# Altium schematic sheet 4inches_DSI_LCD.SchDoc(4inches_DSI_LCD)
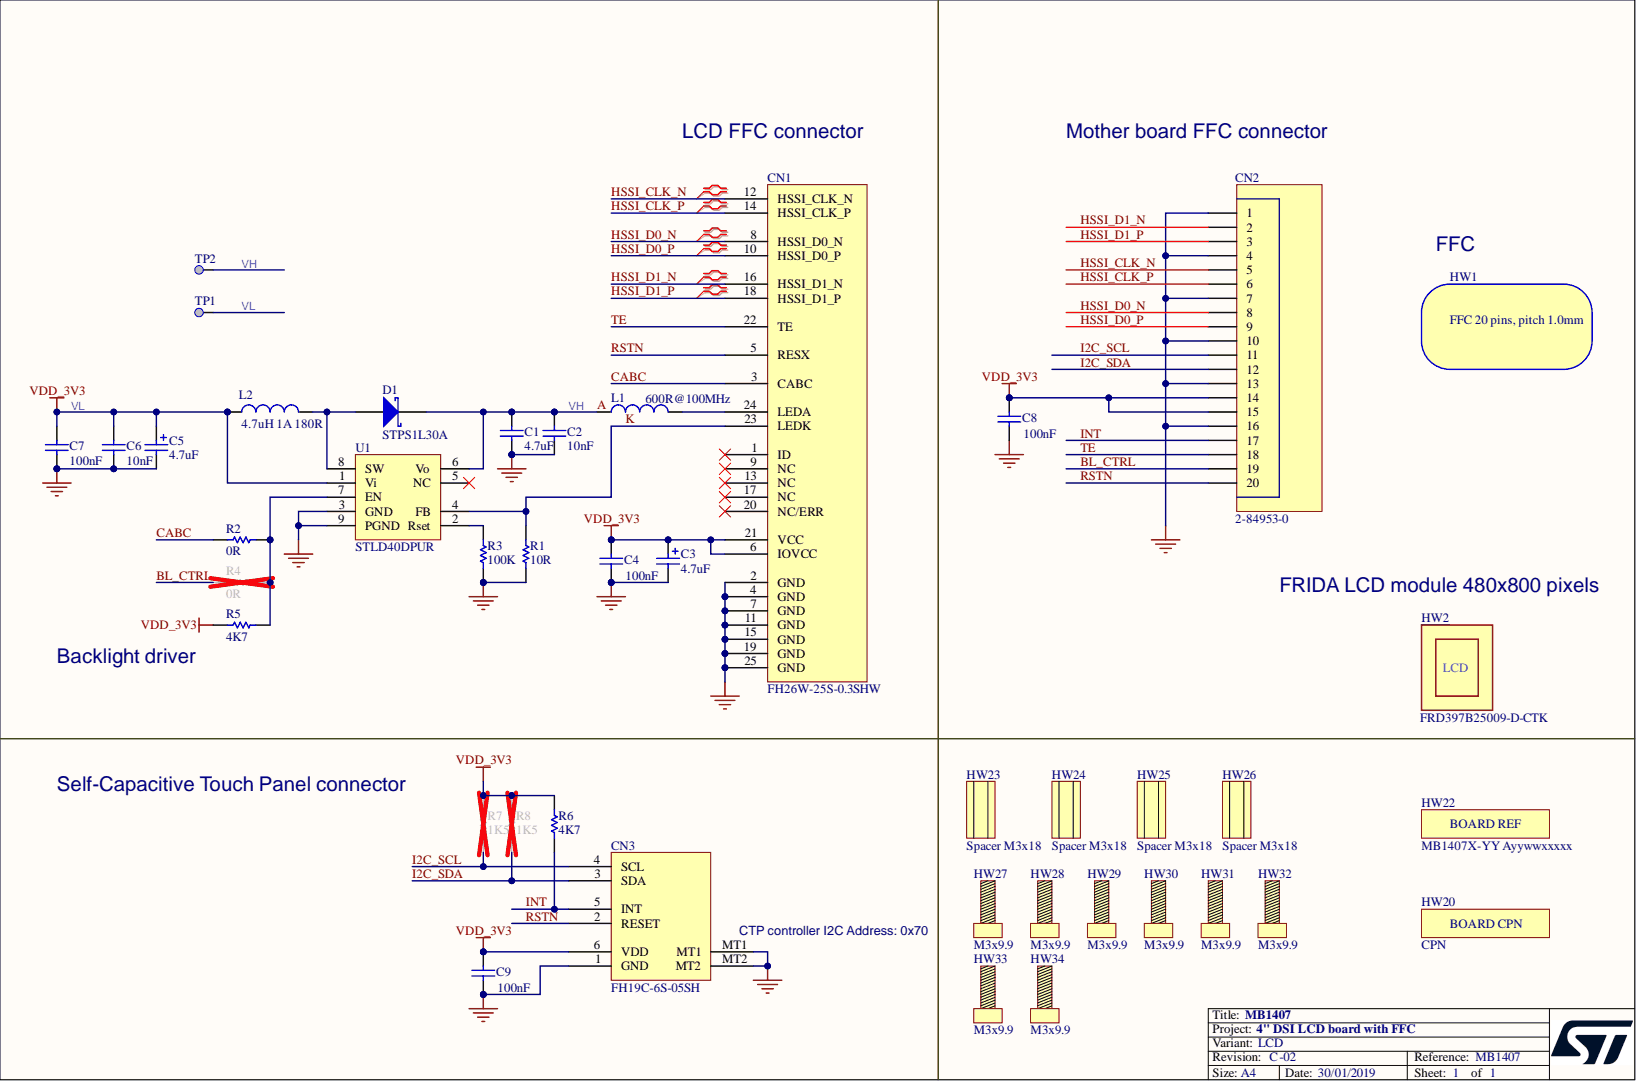
[diagram p0: 4inches_DSI_LCD.SchDoc]

## Parts
C1  value 4.7uF  [C1206_H1P25]  (hidden comment: Capacitor)
C2  value 10nF  [C0402]  (hidden comment: Capacitor)
C3  value 4.7uF  [CPOL_TAJ-A_1206_H180]  (hidden comment: Cap_POL_TANA)
C4  value 100nF  [C0402]  (hidden comment: Capacitor)
C5  value 4.7uF  [CPOL_TAJ-A_1206_H180]  (hidden comment: Cap_POL_TANA)
C6  value 10nF  [C0402]  (hidden comment: Capacitor)
C7  value 100nF  [C0402]  (hidden comment: Capacitor)
C8  value 100nF  [C0402]  (hidden comment: Capacitor)
C9  value 100nF  [C0402]  (hidden comment: Capacitor)
CN1  FH26W-25S-0.3SHW  [CON_HRS_FH26-25S-03SHW]
CN2  value 2-84953-0  Manufacturer_Part_Number: 2-84953-0  [CON_TE-CONNECTIVITY_AMP_2-84953-0]
CN3  FH19C-6S-05SH  [CON_HRS_FH19C-6S-05SH]
D1  value STPS1L30A  Manufacturer_Part_Number: STPS1L30A  [DIO_SMA_1A_2K]  (hidden comment: STPS1L40M)
HW1  FFC 20 pins, pitch 1.0mm  (mechanical)
HW2  value FRD397B25009-D-CTK  Manufacturer_Part_Number: FRD397B25009-D-CTK  (hidden comment: LCD_MODULE)  (mechanical)
HW20  value CPN  [STICKER_BOARD-CPN_25X5]  (hidden comment: ​PCH W35 footprint requested to have a space on board for stickers.)  (no BOM)
HW22  value MB1407X-YY Ayywwxxxxx  [STICKER_BOARD-REF_25X5]  (hidden comment: STICKER_BOARD_REF)  (no BOM)
HW23  Spacer  value Spacer M3x18  [n/a]  (no BOM)
HW24  Spacer  value Spacer M3x18  [n/a]  (no BOM)
HW25  Spacer  value Spacer M3x18  [n/a]  (no BOM)
HW26  Spacer  value Spacer M3x18  [n/a]  (no BOM)
HW27  value M3x9.9  [n/a]  (hidden comment: SCREW)  (no BOM)
HW28  value M3x9.9  [n/a]  (hidden comment: SCREW)  (no BOM)
HW29  value M3x9.9  [n/a]  (hidden comment: SCREW)  (no BOM)
HW30  value M3x9.9  [n/a]  (hidden comment: SCREW)  (no BOM)
HW31  value M3x9.9  [n/a]  (hidden comment: SCREW)  (no BOM)
HW32  value M3x9.9  [n/a]  (hidden comment: SCREW)  (no BOM)
HW33  value M3x9.9  [n/a]  (hidden comment: SCREW)  (no BOM)
HW34  value M3x9.9  [n/a]  (hidden comment: SCREW)  (no BOM)
L1  value 600R@100MHz  [L0603]  (hidden comment: BEAD)
L2  value 4.7uH 1A 180R  [L1210_LQH]  (hidden comment: Inductor)
R1  value 10R  [R0603]  (hidden comment: Resistor)
R2  value 0R  [R0603]  (hidden comment: Resistor)
R3  value 100K  [R0402]  (hidden comment: Resistor)
R4  value 0R  [R0603]  (hidden comment: Resistor)
R5  value 4K7  [R0603]  (hidden comment: Resistor)
R6  value 4K7  [R0603]  (hidden comment: Resistor)
R7  value 1K5  [R0402]
R8  value 1K5  [R0402]
TP1  Test Point  [TP_SMD_1MM]  (value not drawn)  (no BOM)
TP2  Test Point  [TP_SMD_1MM]  (value not drawn)  (no BOM)
U1  STLD40DPUR  [ICC_QFN8-EPAD_3X3_P065]

## Nets
GND: C1.1 C2.1 C3.2 C4.1 C5.2 C6.1 C7.1 C8.1 C9.1 CN1.2(GND) CN1.4(GND) CN1.7(GND) CN1.11(GND) CN1.15(GND) CN1.19(GND) CN1.25(GND) CN2.1 CN2.4 CN2.7 CN2.10 CN2.13 CN2.16 CN3.1(GND) CN3.MT1 CN3.MT2 R1.1 R3.1 U1.3(GND) U1.9(PGND)
A: C1.2 C2.2 D1.2 L1.1 TP2.1 U1.6(Vo)
BL_CTRL: CN2.19 R4.1
CABC: CN1.3(CABC) R2.1
HSSI_CLK_N: CN1.12(HSSI_CLK_N) CN2.5
HSSI_CLK_P: CN1.14(HSSI_CLK_P) CN2.6
HSSI_D0_N: CN1.8(HSSI_D0_N) CN2.8
HSSI_D0_P: CN1.10(HSSI_D0_P) CN2.9
HSSI_D1_N: CN1.16(HSSI_D1_N) CN2.2
HSSI_D1_P: CN1.18(HSSI_D1_P) CN2.3
I2C_SCL: CN2.11 CN3.4(SCL) R7.2
I2C_SDA: CN2.12 CN3.3(SDA) R8.2
INT: CN2.17 CN3.5(INT) R6.1
K: CN1.23(LEDK) R1.2 U1.4(FB)
RSTN: CN1.5(RESX) CN2.20 CN3.2(RESET)
TE: CN1.22(TE) CN2.18
VL: C3.1 C4.2 C5.1 C6.2 C7.2 C8.2 C9.2 CN1.6(IOVCC) CN1.21(VCC) CN2.14 CN2.15 CN3.6(VDD) L2.1 R5.1 R6.2 R7.1 R8.1 TP1.1 U1.1(Vi)
NetCN1_24: CN1.24(LEDA) L1.2
NetD1_1: D1.1 L2.2 U1.8(SW)
NetR2_2: R2.2 R4.2 R5.2 U1.7(EN)
NetR3_2: R3.2 U1.2(Rset)

Not connected: CN1 1(ID) 9(NC) 13(NC) 17(NC) 20(NC/ERR); U1 5(NC)

## Library data of the parts
C1 = MCD-00013: Capacitor, MLCC, 4.7uF, 50V, X7R, 10%, 1206, T=1,27mm; Manufacturer: AVX; Manufacturer_Part_Number: 12065C475KAT2A; Database Table Name: MCD_PARTS$; Initial_Board_Reference: MB1274; Provided_By: Subcon; Component Kind: Standard; ComponentLink1Description: AVX_Capacitor_C0G-NP0-series.pdf; ComponentLink1URL: http://tunmcdsvn.tun.st.com/Component_Datasheet_Library/Trunk/Capacitors/AVX_Capacitor_C0G-NP0-series; ECCN_EU: n/a; ECCN_US: n/a; Internal_Part_Number: MCD-00013; Library Name: MCD_PARTS_database.DbLib; Package: C1206; Part_Type: Capacitor; Status: Released
C2 C6 = MCD-00011: Capacitor, MLCC, 10nF, 16V, X7R, 10%, 0402, T=0.5mm; Manufacturer: YAGEO; Manufacturer_Part_Number: CC0402KRX7R7BB103; Database Table Name: MCD_PARTS$; Initial_Board_Reference: MB1274; Provided_By: Subcon; Component Kind: Standard; ComponentLink1Description: Yageo_Capacitor_X7R-series.pdf; ComponentLink1URL: http://tunmcdsvn.tun.st.com/Component_Datasheet_Library/Trunk/Capacitors/Yageo_Multilayer_Capacitor_X7R_series_100pF_to_22uF; ECCN_EU: n/a; ECCN_US: n/a; Internal_Part_Number: MCD-00011; Library Name: MCD_PARTS_database.DbLib; Package: C0402; Part_Type: Capacitor; Status: Released
C3 C5 = MCD-00007: Capacitor, Tantalum, 4.7uF, 16V, 10%, TypeA; Manufacturer: AVX; Manufacturer_Part_Number: TAJA475K016R; Database Table Name: MCD_PARTS$; Initial_Board_Reference: MB1274; Provided_By: Subcon; Component Kind: Standard; ComponentLink1Description: AVX_Capacitor_TAJ-series.pdf; ComponentLink1URL: http://tunmcdsvn.tun.st.com/Component_Datasheet_Library/Trunk/Capacitors/AVX_Capacitor_TAJ-series; ECCN_EU: n/a; ECCN_US: n/a; Internal_Part_Number: MCD-00007; Library Name: MCD_PARTS_database.DbLib; Package: C1206; Part_Type: Capacitor; Revision: July-2002: Re-released for DXP Platform.; Status: Released
C4 C7-C9 = MCD-00006: Capacitor, MLCC, 100nF, 16V, X7R, 10%, 0402, T=0.5mm; Manufacturer: YAGEO; Manufacturer_Part_Number: CC0402KRX7R7BB104; Database Table Name: MCD_PARTS$; Initial_Board_Reference: MB1274; Provided_By: Subcon; Component Kind: Standard; ComponentLink1Description: Yageo_Capacitor_X7R-series.pdf; ComponentLink1URL: http://tunmcdsvn.tun.st.com/Component_Datasheet_Library/Trunk/Capacitors/Yageo_Multilayer_Capacitor_X7R_series_100pF_to_22uF; ECCN_EU: n/a; ECCN_US: n/a; Internal_Part_Number: MCD-00006; Library Name: MCD_PARTS_database.DbLib; Package: C0402; Part_Type: Capacitor; Status: Released
CN1 = MCD-01333: FPC connector FH26 series 0.3mm pitch,1mm height; Manufacturer: HIROSE; Database Table Name: MCD_PARTS$; Initial_Board_Reference: MB1407; Provided_By: Subcon; Component Kind: Standard; ComponentLink1Description: Datasheet; ComponentLink1URL: http://tunmcdsvn.tun.st.com/Component_Datasheet_Library/Trunk/Connectors/Hirose_connector_FH26-series/Hirose_FH26_series.pdf; ECCN_EU: n/a; ECCN_US: n/a; Internal_Part_Number: MCD-01333; Library Name: MCD_PARTS_database.DbLib; Manufacturer_Part_Number: FH26W-25S-0.3SHW; Part_Type: Connector; Status: Footprint available; Value: FH26W-25S-0.3SHW
CN2 = MCD-01234: FPC, 20pins, 1.0mm,SMT, ZIF Horizontal.; Manufacturer: TECONNECTIVITY; Part_Type: Connector; Database Table Name: MCD_PARTS$; Initial_Board_Reference: MB1407; Provided_By: Subcon; Component Kind: Standard; ComponentLink1Description: Datasheet; ComponentLink1URL: http://tunmcdsvn.tun.st.com/Component_Datasheet_Library/Trunk/Connectors/TE_Connectivity_FPC_2-84953-0; ECCN_EU: n/a; ECCN_US: n/a; Internal_Part_Number: MCD-01234; Library Name: MCD_PARTS_database.DbLib; Status: New
CN3 = MCD-01235: FPC,FH19C-6S-05SH,0.5mm,6P,H=2.0mm,SMT..; Manufacturer: HIROSE; Part_Type: Connector; Database Table Name: MCD_PARTS$; Initial_Board_Reference: MB1407; Item Type: Item; Path: ws/MCD_ApplicationBoards/Lists/MCDPARTSdatabase; Provided_By: SUBCO; Remark: symbol schematic to update to be in line with the TP of MB1407; Component Kind: Standard; ComponentLink1Description: Datasheet; ComponentLink1URL: http://tunmcdsvn.tun.st.com/Component_Datasheet_Library/Trunk/Connectors/Hirose_Connector_FH19-series; Internal_Part_Number: MCD-01235; Library Name: MCD_PARTS_database.DbLib; Manufacturer_Part_Number: FH19C-6S-05SH; Status: Footprint available; Value: FH19C-6S-05SH
D1 = MCD-01059: LOW DROP POWER SCHOTTKY RECTIFIER 1A 30V VF=0.3V; Manufacturer: STMICROELECTRONICS; Part_Type: Diode; Database Table Name: MCD_PARTS$; Initial_Board_Reference: MB1378; Provided_By: STM; Component Kind: Standard; ComponentLink1Description: Datasheet; ComponentLink1URL: http://tunmcdsvn.tun.st.com/Component_Datasheet_Library/Trunk/Diodes/STM_SCHOTTKY_STPS1L30; Internal_Part_Number: MCD-01059; Library Name: MCD_PARTS_database.DbLib; Package: DIO_SMA_1A_2K; Status: Footprint available
HW1 = MCD-03264: 1.00mm Pitch Premo-Flex FFC Jumper, Same Side Contacts (Type A), 51.00mm Cable Length, Tin (Sn) Plating, 20 Circuits; Manufacturer: MOLEX; Manufacturer_Part_Number: 152670357; Part_Preference: Recommended; Part_Type: Mechanical; Component_Country_Of_Origin: Hungary; Database Table Name: MCD_PARTS$; ID: 5380; ShowFields: YES; ComponentLink1URL: http://tunmcdsvn.tun.st.com/Component_Documentation_Library/Trunk/Connector/MOLEX_152670357; Internal_Part_Number: MCD-03264; Library Name: MCD_PARTS_database.DbLib; Order_Code_Digikey: WM10549-ND; Order_Code_Farnell: 3862286; Order_Code_RS: N/A; Status: Checked
HW2 = MCD-02034: LCD, CTP, 3.97-inch, 480*(RGB)*320; Manufacturer: SHENZEN FRIDA LCD CO.LTD; Part_Preference: Forbidden; Part_Type: Display; Database Table Name: MCD_PARTS$; ID: 4134; ShowFields: YES; ComponentLink1URL: http://tunmcdsvn.tun.st.com/Component_Datasheet_Library/Trunk/Display/FRIDA_LCD_FRD397B25009-D-CTK; Internal_Part_Number: MCD-02034; Library Name: MCD_PARTS_database.DbLib; Order_Code_Digikey: N/A; Order_Code_Farnell: N/A; Order_Code_RS: N/A; Status: Obsolete
HW20 = MCD-00547: Sticker to mention board CPN code; Manufacturer: NA; Part_Type: Mechanical; Database Table Name: MCD_PARTS$; Initial_Board_Reference: MB1319; Provided_By: Subcon; ComponentLink1Description: NA; ComponentLink1URL: NA; ECCN_EU: n/a; ECCN_US: n/a; Internal_Part_Number: MCD-00547; Library Name: MCD_PARTS_database.DbLib; Manufacturer_Part_Number: NA; Status: Footprint available
HW22 = MCD-00235: Sticker to mention board reference + serial number; Manufacturer: NA; Part_Type: Mechanical; Database Table Name: MCD_PARTS$; Initial_Board_Reference: MB1319; Provided_By: Subcon; ComponentLink1Description: NA; ComponentLink1URL: NA; ECCN_EU: n/a; ECCN_US: n/a; Internal_Part_Number: MCD-00235; Library Name: MCD_PARTS_database.DbLib; Manufacturer_Part_Number: NA; Status: Footprint available
HW23-HW26 = MCD-01686: Standoff, Hexagonal ,Nylon, M3x18; Manufacturer: Keystone Electronics; Manufacturer_Part_Number: 25513; Part_Type: Mechanical; Database Table Name: MCD_PARTS$; Provided_By: SUBCO; ComponentLink1Description: Datasheet; ComponentLink1URL: http://tunmcdsvn.tun.st.com/Component_Datasheet_Library/Trunk/Mechanical/KEYSTONE_spacer_M3x18_25513/KEYSTONE_spacer_M3x18_25513.PDF; Internal_Part_Number: MCD-01686; Library Name: MCD_PARTS_database.DbLib; Package: n/a; Status: Released
HW27-HW34 = MCD-01687: Screw, Nylon , M3x9.9mm; Manufacturer: FASTENINGS; Manufacturer_Part_Number: TRNMS 310 01; Part_Type: Mechanical; Database Table Name: MCD_PARTS$; Provided_By: SUBCO; ComponentLink1Description: Datasheet; ComponentLink1URL: http://tunmcdsvn.tun.st.com/Component_Datasheet_Library/Trunk/Mechanical/FASTENING_screw_M3x9.9_TRNMS31001; Internal_Part_Number: MCD-01687; Library Name: MCD_PARTS_database.DbLib; Order_Code_Farnell: 2472679; Status: Released
L1 = MCD-00047: SMD Chip ferrite bead, 600R@100MHz +/-25%, 350mA, 500mohm DC, 0603, T=0.8mm; Manufacturer: TAI-TECH; Manufacturer_Part_Number: FCM1608KF-601T03; Part_Type: Inductor; Database Table Name: MCD_PARTS$; Initial_Board_Reference: MB1274; Provided_By: Subcon; Component Kind: Standard; ComponentLink1Description: TaiTech_Bead_FCM1608_series.pdf; ComponentLink1URL: http://tunmcdsvn.tun.st.com/Component_Datasheet_Library/Trunk/Inductors/TaiTech_Bead_FCM1608_series; ECCN_EU: n/a; ECCN_US: n/a; Internal_Part_Number: MCD-00047; Library Name: MCD_PARTS_database.DbLib; Status: Released
L2 = MCD-00048: FIXED IND 4.7UH 1A 180 MOHM 30% SMD; Manufacturer: MURATA; Manufacturer_Part_Number: LQH32PN4R7NN0L; Database Table Name: MCD_PARTS$; Initial_Board_Reference: MB1274; Provided_By: Subcon; Component Kind: Standard; ComponentLink1Description: Murata_Inductor_LQH32PN4R7NN0L.pdf; ComponentLink1URL: http://tunmcdsvn.tun.st.com/Component_Datasheet_Library/Trunk/Inductors/Murata_Inductor_LQH32PN4R7NN0L; ECCN_EU: n/a; ECCN_US: n/a; Internal_Part_Number: MCD-00048; Library Name: MCD_PARTS_database.DbLib; Part_Type: Inductor; Status: Released
R1 = MCD-00060: Resistor,10R,0603,1%,0.25W; Manufacturer: ROHM; Manufacturer_Part_Number: ESR03EZPF10R0; Database Table Name: MCD_PARTS$; Initial_Board_Reference: MB1274; Provided_By: Subcon; Component Kind: Standard; ComponentLink1Description: ROHM_Resistor_ESR-series.pdf; ComponentLink1URL: http://tunmcdsvn.tun.st.com/Component_Datasheet_Library/Trunk/Resistors/ROHM_Resistor_ESR-series; ECCN_EU: n/a; ECCN_US: n/a; Internal_Part_Number: MCD-00060; Library Name: MCD_PARTS_database.DbLib; Package: R0603; Part_Type: Resistor; Status: Released
R2 R4 = MCD-00056: Resistor,0R,0603,5%,1/10W; Manufacturer: YAGEO; Manufacturer_Part_Number: RC0603JR-070RL; Database Table Name: MCD_PARTS$; Initial_Board_Reference: MB1274; Provided_By: subcon; Component Kind: Standard; ComponentLink1Description: Yageo_Resistor_RC0603-series.pdf; ComponentLink1URL: http://tunmcdsvn.tun.st.com/Component_Datasheet_Library/Trunk/Resistors/Yageo_Resistor_RC0603-series; ECCN_EU: n/a; ECCN_US: n/a; Internal_Part_Number: MCD-00056; Library Name: MCD_PARTS_database.DbLib; Package: R0603; Part_Type: Resistor; Status: Released
R3 = MCD-00059: Resistor,100K,0402,1%,1/16W; Alternate_Manufacturer: YAGEO; Alternate_Manufacturer_Part_Number: RT0402FRE07100KL; Manufacturer_Part_Number: RC0402FR-07100KL; Database Table Name: MCD_PARTS$; Initial_Board_Reference: MB1274; Provided_By: Subcon; Component Kind: Standard; ComponentLink1Description: Yageo_Resistor_RC0402-series.pdf; ComponentLink1URL: http://tunmcdsvn.tun.st.com/Component_Datasheet_Library/Trunk/Resistors/Yageo_Resistor_RC0402-series; ECCN_EU: n/a; ECCN_US: n/a; Internal_Part_Number: MCD-00059; Library Name: MCD_PARTS_database.DbLib; Manufacturer: YAGEO; Package: R0402; Part_Type: Resistor; Status: Released
R5 R6 = MCD-00219: Resistor,4K7,0603,1%,1/10W; Manufacturer: YAGEO; Manufacturer_Part_Number: RC0603FR-074K7L; Database Table Name: MCD_PARTS$; Initial_Board_Reference: MB1319; Provided_By: Subcon; Component Kind: Standard; ComponentLink1Description: Yageo_Resistor_RC0603-series.pdf; ComponentLink1URL: http://tunmcdsvn.tun.st.com/Component_Datasheet_Library/Trunk/Resistors/Yageo_Resistor_RC0603-series; ECCN_EU: n/a; ECCN_US: n/a; Internal_Part_Number: MCD-00219; Library Name: MCD_PARTS_database.DbLib; Package: R0603; Part_Type: Resistor; Status: Released
R7 R8 = MCD-00068: Resistor,1K5,0402,1%,1/16W; Manufacturer: YAGEO; Manufacturer_Part_Number: RC0402FR-071K5L; Database Table Name: MCD_PARTS$; Initial_Board_Reference: mb1274; Provided_By: Subcon; Component Kind: Standard; ComponentLink1Description: Yageo_Resistor_RC0402-series.pdf; ComponentLink1URL: http://tunmcdsvn.tun.st.com/Component_Datasheet_Library/Trunk/Resistors/Yageo_Resistor_RC0402-series; ECCN_EU: n/a; ECCN_US: n/a; Internal_Part_Number: MCD-00068; Library Name: MCD_PARTS_database.DbLib; Package: R0402; Part_Type: Resistor; Status: Released
TP1 TP2 = MCD-00074: Test Point 1mm; Part_Type: Mechanical; Database Table Name: MCD_PARTS$; Initial_Board_Reference: MB1274; ComponentLink1Description: n/a; ComponentLink1URL: http://na; ECCN_EU: n/a; ECCN_US: n/a; Internal_Part_Number: MCD-00074; Library Name: MCD_PARTS_database.DbLib; Manufacturer: n/a; Manufacturer_Part_Number: n/a; Provided_By: n/a; Status: Released; Value: 1mm
U1 = MCD-00082: White LED power supply for large display backlight, QFN8; Manufacturer: STMICROELECTRONICS; Part_Type: IC; Database Table Name: MCD_PARTS$; ECCN_EU: NEC; ECCN_US: EAR99; Initial_Board_Reference: MB1274; Provided_By: Subcon; Component Kind: Standard; ComponentLink1Description: STM_White-LED-PWR_STLD40DPUR_QFN8.pdf; ComponentLink1URL: http://tunmcdsvn.tun.st.com/Component_Datasheet_Library/Trunk/IC/STM_White-LED-PWR_STLD40DPUR_QFN8; Internal_Part_Number: MCD-00082; Library Name: MCD_PARTS_database.DbLib; Manufacturer_Part_Number: STLD40DPUR; Status: Released; Value: STLD40DPUR
